# Altium schematic sheet [19]-CAMERA_PWR_CONNECTORS.SchDoc([19]-CAMERA_PWR_CONNECTORS)
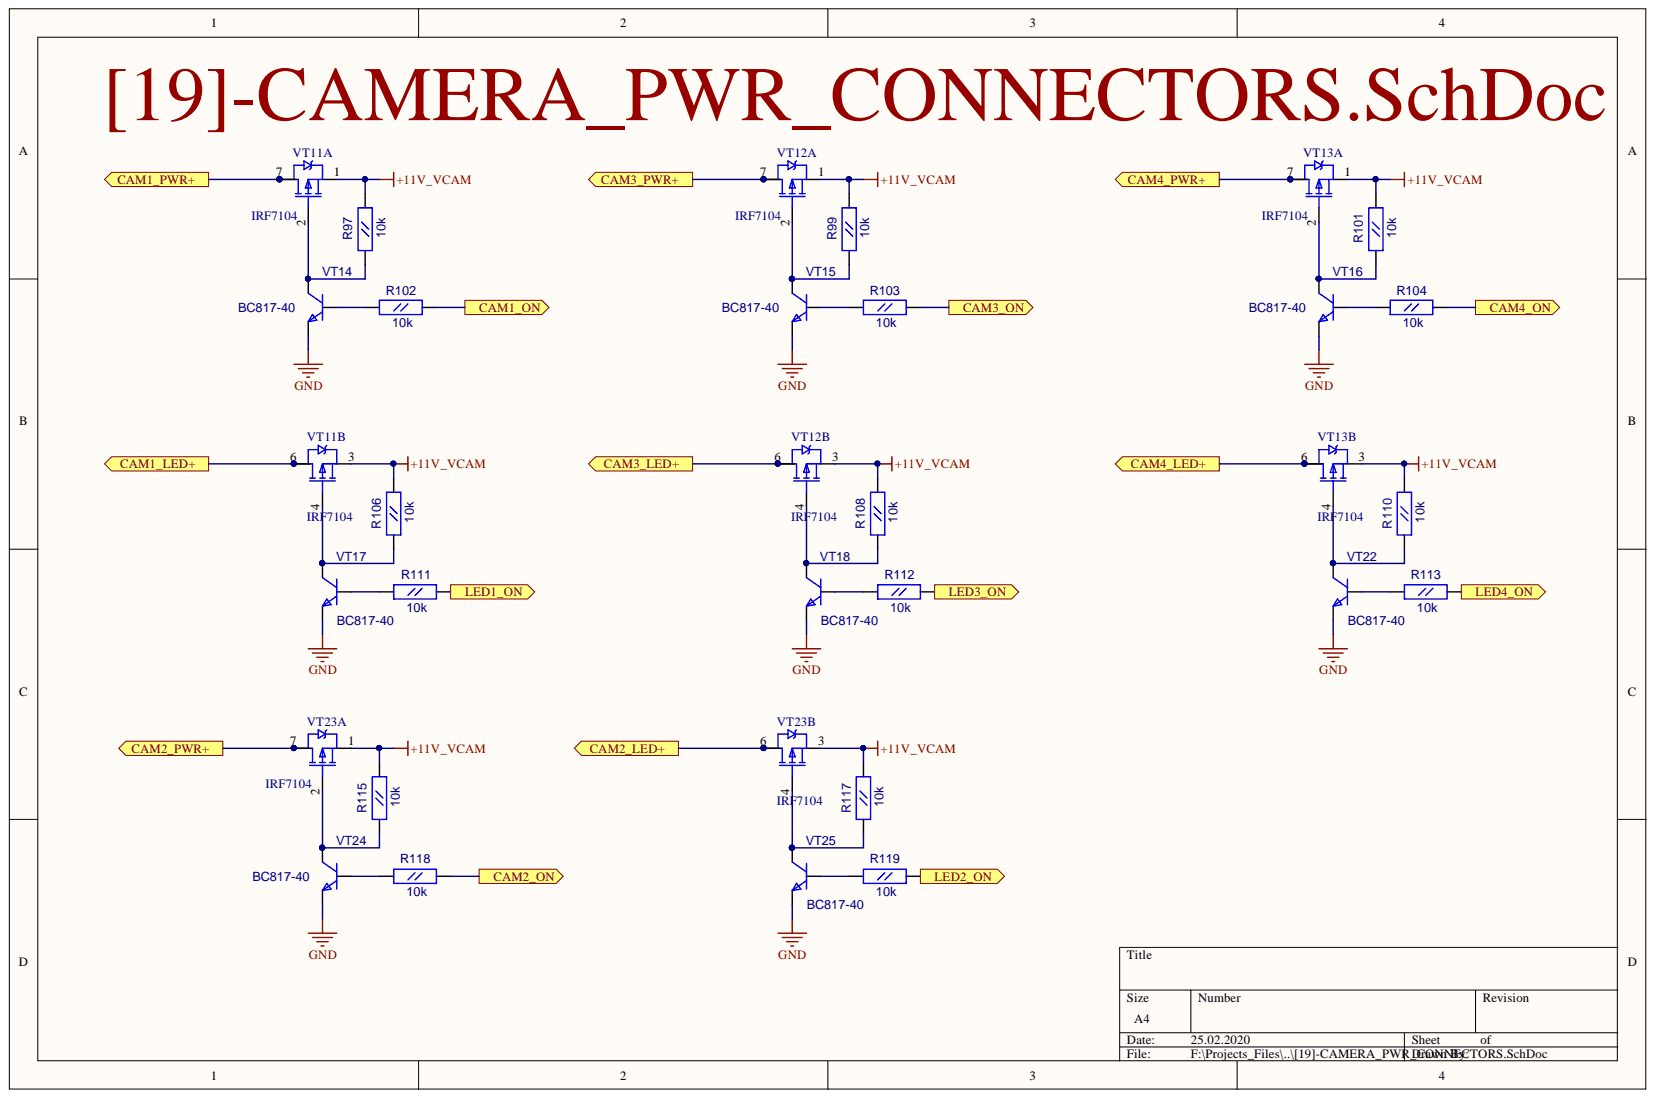
[diagram p0: [19]-CAMERA_PWR_CONNECTORS.SchDoc]

## Parts
R97  10k  [RC0603]
R99  10k  [RC0603]
R101  10k  [RC0603]
R102  10k  [RC0603]
R103  10k  [RC0603]
R104  10k  [RC0603]
R106  10k  [RC0603]
R108  10k  [RC0603]
R110  10k  [RC0603]
R111  10k  [RC0603]
R112  10k  [RC0603]
R113  10k  [RC0603]
R115  10k  [RC0603]
R117  10k  [RC0603]
R118  10k  [RC0603]
R119  10k  [RC0603]
VT11  IRF7104  [SO-8]  (parts A,B)
VT12  IRF7104  [SO-8]  (parts A,B)
VT13  IRF7104  [SO-8]  (parts A,B)
VT14  BC817-40  [SOT-23(123)]
VT15  BC817-40  [SOT-23(123)]
VT16  BC817-40  [SOT-23(123)]
VT17  BC817-40  [SOT-23(123)]
VT18  BC817-40  [SOT-23(123)]
VT22  BC817-40  [SOT-23(123)]
VT23  IRF7104  [SO-8]  (parts A,B)
VT24  BC817-40  [SOT-23(123)]
VT25  BC817-40  [SOT-23(123)]

## Ports
CAM1_LED+, CAM1_ON, CAM1_PWR+, CAM2_LED+, CAM2_ON, CAM2_PWR+, CAM3_LED+, CAM3_ON, CAM3_PWR+, CAM4_LED+, CAM4_ON, CAM4_PWR+, LED1_ON, LED2_ON, LED3_ON, LED4_ON

## Nets
+11V_VCAM: R97.2 R99.2 R101.2 R106.2 R108.2 R110.2 R115.2 R117.2 VT11.1 VT11.3 VT12.1 VT12.3 VT13.1 VT13.3 VT23.1 VT23.3
GND: VT14.2 VT15.2 VT16.2 VT17.2 VT18.2 VT22.2 VT24.2 VT25.2
CAM1_LED+: VT11.5 VT11.6 ; port CAM1_LED+
CAM1_ON: R102.2 ; port CAM1_ON
CAM1_PWR+: VT11.7 VT11.8 ; port CAM1_PWR+
CAM2_LED+: VT23.5 VT23.6 ; port CAM2_LED+
CAM2_ON: R118.2 ; port CAM2_ON
CAM2_PWR+: VT23.7 VT23.8 ; port CAM2_PWR+
CAM3_LED+: VT12.5 VT12.6 ; port CAM3_LED+
CAM3_ON: R103.2 ; port CAM3_ON
CAM3_PWR+: VT12.7 VT12.8 ; port CAM3_PWR+
CAM4_LED+: VT13.5 VT13.6 ; port CAM4_LED+
CAM4_ON: R104.2 ; port CAM4_ON
CAM4_PWR+: VT13.7 VT13.8 ; port CAM4_PWR+
LED1_ON: R111.2 ; port LED1_ON
LED2_ON: R119.2 ; port LED2_ON
LED3_ON: R112.2 ; port LED3_ON
LED4_ON: R113.2 ; port LED4_ON
NetR97_1: R97.1 VT11.2 VT14.3
NetR99_1: R99.1 VT12.2 VT15.3
NetR101_1: R101.1 VT13.2 VT16.3
NetR102_1: R102.1 VT14.1
NetR103_1: R103.1 VT15.1
NetR104_1: R104.1 VT16.1
NetR106_1: R106.1 VT11.4 VT17.3
NetR108_1: R108.1 VT12.4 VT18.3
NetR110_1: R110.1 VT13.4 VT22.3
NetR111_1: R111.1 VT17.1
NetR112_1: R112.1 VT18.1
NetR113_1: R113.1 VT22.1
NetR115_1: R115.1 VT23.2 VT24.3
NetR117_1: R117.1 VT23.4 VT25.3
NetR118_1: R118.1 VT24.1
NetR119_1: R119.1 VT25.1

## Library data of the parts
R97 R99 R101-R104 R106 R108 R110-R113 R115 R117-R119 = RC0603: Value: 10k; Description: RC0603; Component Kind: Standard; Library Name: fizik_lib.SchLib
VT11-VT13 VT23 = IRF7104: Dual P-channel MOSFET. 20V,2.3A, 0.25Ohm; Value: IRF7104; Component Kind: Standard; Library Name: fizik_lib (1).SchLib
VT14-VT18 VT22 VT24 VT25 = BC846BLT1: NPN 500ma transistor; ComponentField7: Published 29-Sep-2000; Value: BC817-40; Simulation: BC846BLT1; OriginalName: BC846BLT1; Component Kind: Standard; Library Name: fizik_lib.SchLib
